# Altium schematic sheet [5] FPGA.SchDoc("[5] FPGA")
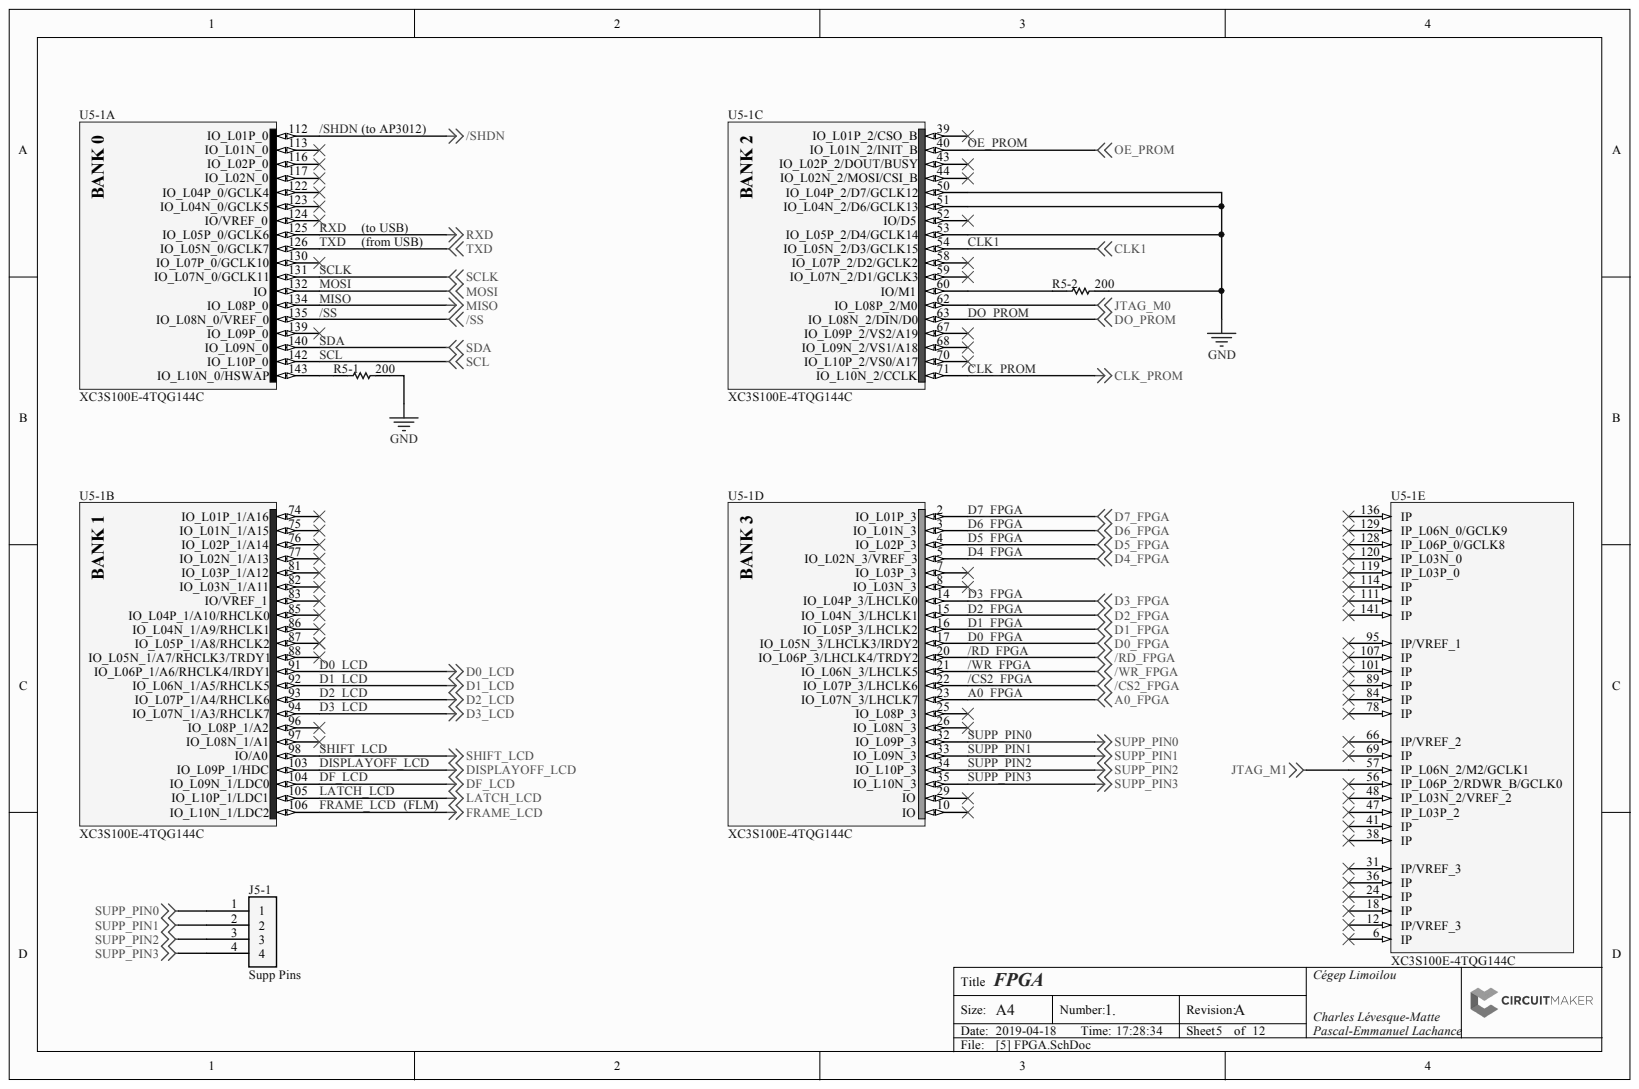
[diagram p0: [5] FPGA.SchDoc]

## Parts
J5-1  Supp Pins  [PCB-3tidce7oy5ehsf0b4mi0-1]
R5-1  200  [PCB-u0msi5n9597hlhe0i4x3-1]
R5-2  200  [PCB-u0msi5n9597hlhe0i4x3-1]
U5-1  XC3S100E-4TQG144C  [TQG144_L]  (parts A,B,C,D,E)

## Nets
GND: R5-1.2 R5-2.2 U5-1.50(IO_L04P_2/D7/GCLK12) U5-1.51(IO_L04N_2/D6/GCLK13) U5-1.53(IO_L05P_2/D4/GCLK14)
JTAG_M0: U5-1.62(IO_L08P_2/M0)
JTAG_M1: U5-1.57(IP_L06N_2/M2/GCLK1)
/CS2_FPGA: U5-1.22(IO_L07P_3/LHCLK6)
/RD_FPGA: U5-1.20(IO_L06P_3/LHCLK4/TRDY2)
/SHDN: U5-1.112(IO_L01P_0)
/SS: U5-1.135(IO_L08N_0/VREF_0)
/WR_FPGA: U5-1.21(IO_L06N_3/LHCLK5)
A0_FPGA: U5-1.23(IO_L07N_3/LHCLK7)
CLK1: U5-1.54(IO_L05N_2/D3/GCLK15)
CLK_PROM: U5-1.71(IO_L10N_2/CCLK)
D0_FPGA: U5-1.17(IO_L05N_3/LHCLK3/IRDY2)
D0_LCD: U5-1.91(IO_L06P_1/A6/RHCLK4/IRDY1)
D1_FPGA: U5-1.16(IO_L05P_3/LHCLK2)
D1_LCD: U5-1.92(IO_L06N_1/A5/RHCLK5)
D2_FPGA: U5-1.15(IO_L04N_3/LHCLK1)
D2_LCD: U5-1.93(IO_L07P_1/A4/RHCLK6)
D3_FPGA: U5-1.14(IO_L04P_3/LHCLK0)
D3_LCD: U5-1.94(IO_L07N_1/A3/RHCLK7)
D4_FPGA: U5-1.5(IO_L02N_3/VREF_3)
D5_FPGA: U5-1.4(IO_L02P_3)
D6_FPGA: U5-1.3(IO_L01N_3)
D7_FPGA: U5-1.2(IO_L01P_3)
DF_LCD: U5-1.104(IO_L09N_1/LDC0)
DISPLAYOFF_LCD: U5-1.103(IO_L09P_1/HDC)
DO_PROM: U5-1.63(IO_L08N_2/DIN/D0)
FRAME_LCD: U5-1.106(IO_L10N_1/LDC2)
LATCH_LCD: U5-1.105(IO_L10P_1/LDC1)
MISO: U5-1.134(IO_L08P_0)
MOSI: U5-1.132(IO)
OE_PROM: U5-1.40(IO_L01N_2/INIT_B)
RXD: U5-1.125(IO_L05P_0/GCLK6)
SCL: U5-1.142(IO_L10P_0)
SCLK: U5-1.131(IO_L07N_0/GCLK11)
SDA: U5-1.140(IO_L09N_0)
SHIFT_LCD: U5-1.98(IO/A0)
SUPP_PIN0: J5-1.1 U5-1.32(IO_L09P_3)
SUPP_PIN1: J5-1.2 U5-1.33(IO_L09N_3)
SUPP_PIN2: J5-1.3 U5-1.34(IO_L10P_3)
SUPP_PIN3: J5-1.4 U5-1.35(IO_L10N_3)
TXD: U5-1.126(IO_L05N_0/GCLK7)
NetR5-1_1: R5-1.1 U5-1.143(IO_L10N_0/HSWAP)
NetR5-2_1: R5-2.1 U5-1.60(IO/M1)

Not connected: U5-1 6(IP) 7(IO_L03P_3) 8(IO_L03N_3) 10(IO) 12(IP/VREF_3) 18(IP) 24(IP) 25(IO_L08P_3) 26(IO_L08N_3) 29(IO) 31(IP/VREF_3) 36(IP) 38(IP) 39(IO_L01P_2/CSO_B) 41(IP) 43(IO_L02P_2/DOUT/BUSY) 44(IO_L02N_2/MOSI/CSI_B) 47(IP_L03P_2) 48(IP_L03N_2/VREF_2) 52(IO/D5) 56(IP_L06P_2/RDWR_B/GCLK0) 58(IO_L07P_2/D2/GCLK2) 59(IO_L07N_2/D1/GCLK3) 66(IP/VREF_2) 67(IO_L09P_2/VS2/A19) 68(IO_L09N_2/VS1/A18) 69(IP) 70(IO_L10P_2/VS0/A17) 74(IO_L01P_1/A16) 75(IO_L01N_1/A15) 76(IO_L02P_1/A14) 77(IO_L02N_1/A13) 78(IP) 81(IO_L03P_1/A12) 82(IO_L03N_1/A11) 83(IO/VREF_1) 84(IP) 85(IO_L04P_1/A10/RHCLK0) 86(IO_L04N_1/A9/RHCLK1) 87(IO_L05P_1/A8/RHCLK2) 88(IO_L05N_1/A7/RHCLK3/TRDY1) 89(IP) 95(IP/VREF_1) 96(IO_L08P_1/A2) 97(IO_L08N_1/A1) 101(IP) 107(IP) 111(IP) 113(IO_L01N_0) 114(IP) 116(IO_L02P_0) 117(IO_L02N_0) 119(IP_L03P_0) 120(IP_L03N_0) 122(IO_L04P_0/GCLK4) 123(IO_L04N_0/GCLK5) 124(IO/VREF_0) 128(IP_L06P_0/GCLK8) 129(IP_L06N_0/GCLK9) 130(IO_L07P_0/GCLK10) 136(IP) 139(IO_L09P_0) 141(IP)

## Library data of the parts
J5-1 = CMP-hulhyd9i8mmkyyurut70-1: footprintforsupplementarytestpins; Component Kind: Standard; CustomComponentID: hulhyd9i8mmkyyurut70; Library Name: FavoritesLibrary
R5-1 R5-2 = CMP-f67e3e51f81629b9-1: Res Thick Film 0603 200 Ohm 1% 0.1W(1/10W) ±100ppm/C Pad SMD Automotive T/R; Manufacturer Part Number: RMCF0603FT200R; Lead-Free Status: Lead Free; Power Rating: 100 mW; Resistance: 200 Ω; Case/Package: 0603; Component Kind: Standard; Library Name: CommunityVault; Mounting Style: Surface Mount; OctopartID: f67e3e51f81629b9; Octopart URL: https://octopart.com/rmcf0603ft200r-stackpole+electronics-19213070; Packaging: Tape & Reel (TR); Resistance Tolerance: ±1%; RoHS: Compliant
U5-1 = CMP-971465-2: Spartan-3E 1.2V FPGA, 108 User I/Os, 144-Pin TQFP, Standard Performance, Commercial Grade, Pb-Free; Lead-Free Status: Lead Free; Number of Gates: 100000; Number of I/O Pins: 108; RAM Memory Size: 9216 B; Case/Package: TQFP; Component Kind: Standard; Library Name: CommunityVault; Manufacturer Part Number: XC3S100E-4TQG144C; Mounting Style: Surface Mount; Number of Pins: 144; OctopartID: 2992e09f0182f655; Octopart Url: https://octopart.com/xc3s100e-4tqg144c-xilinx-462198; Packaging: Tray; RoHS: Compliant; Supply Voltage (DC): 1.2 V
